# Altium schematic sheet STM32.SchDoc(STM32)
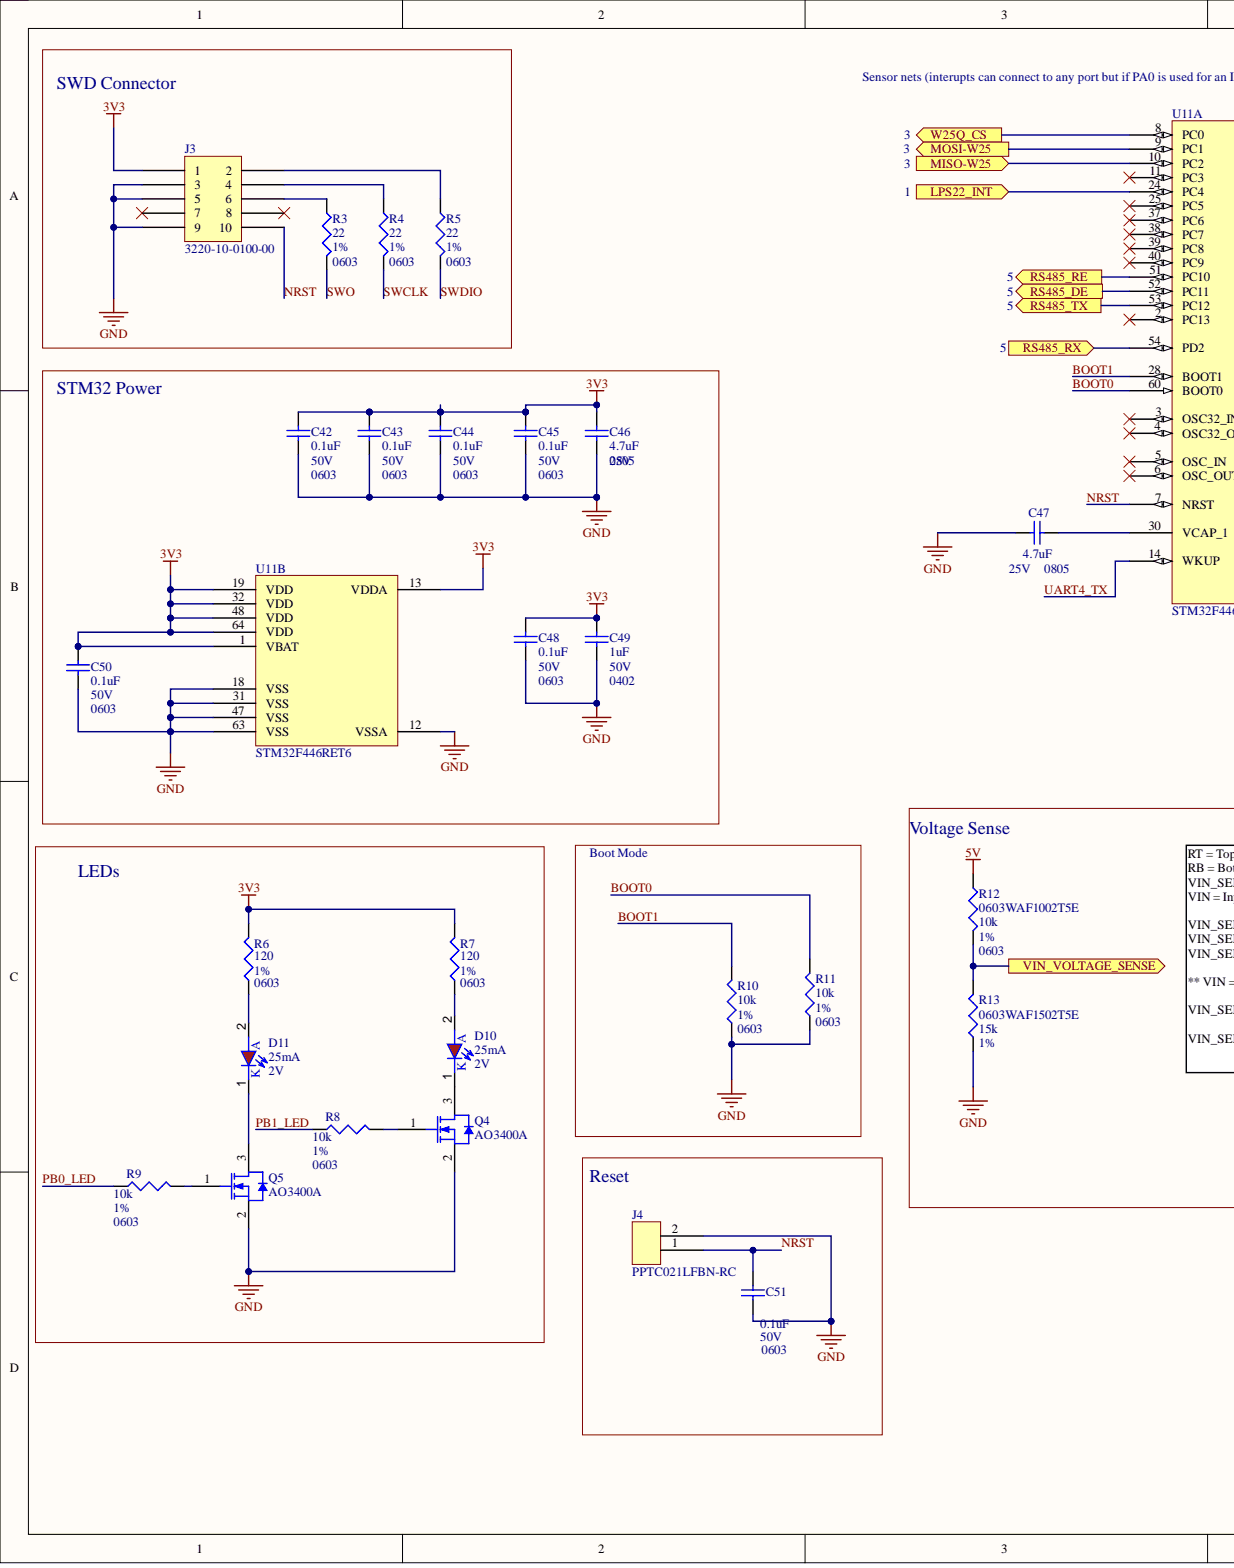
[diagram p0: STM32.SchDoc, left]
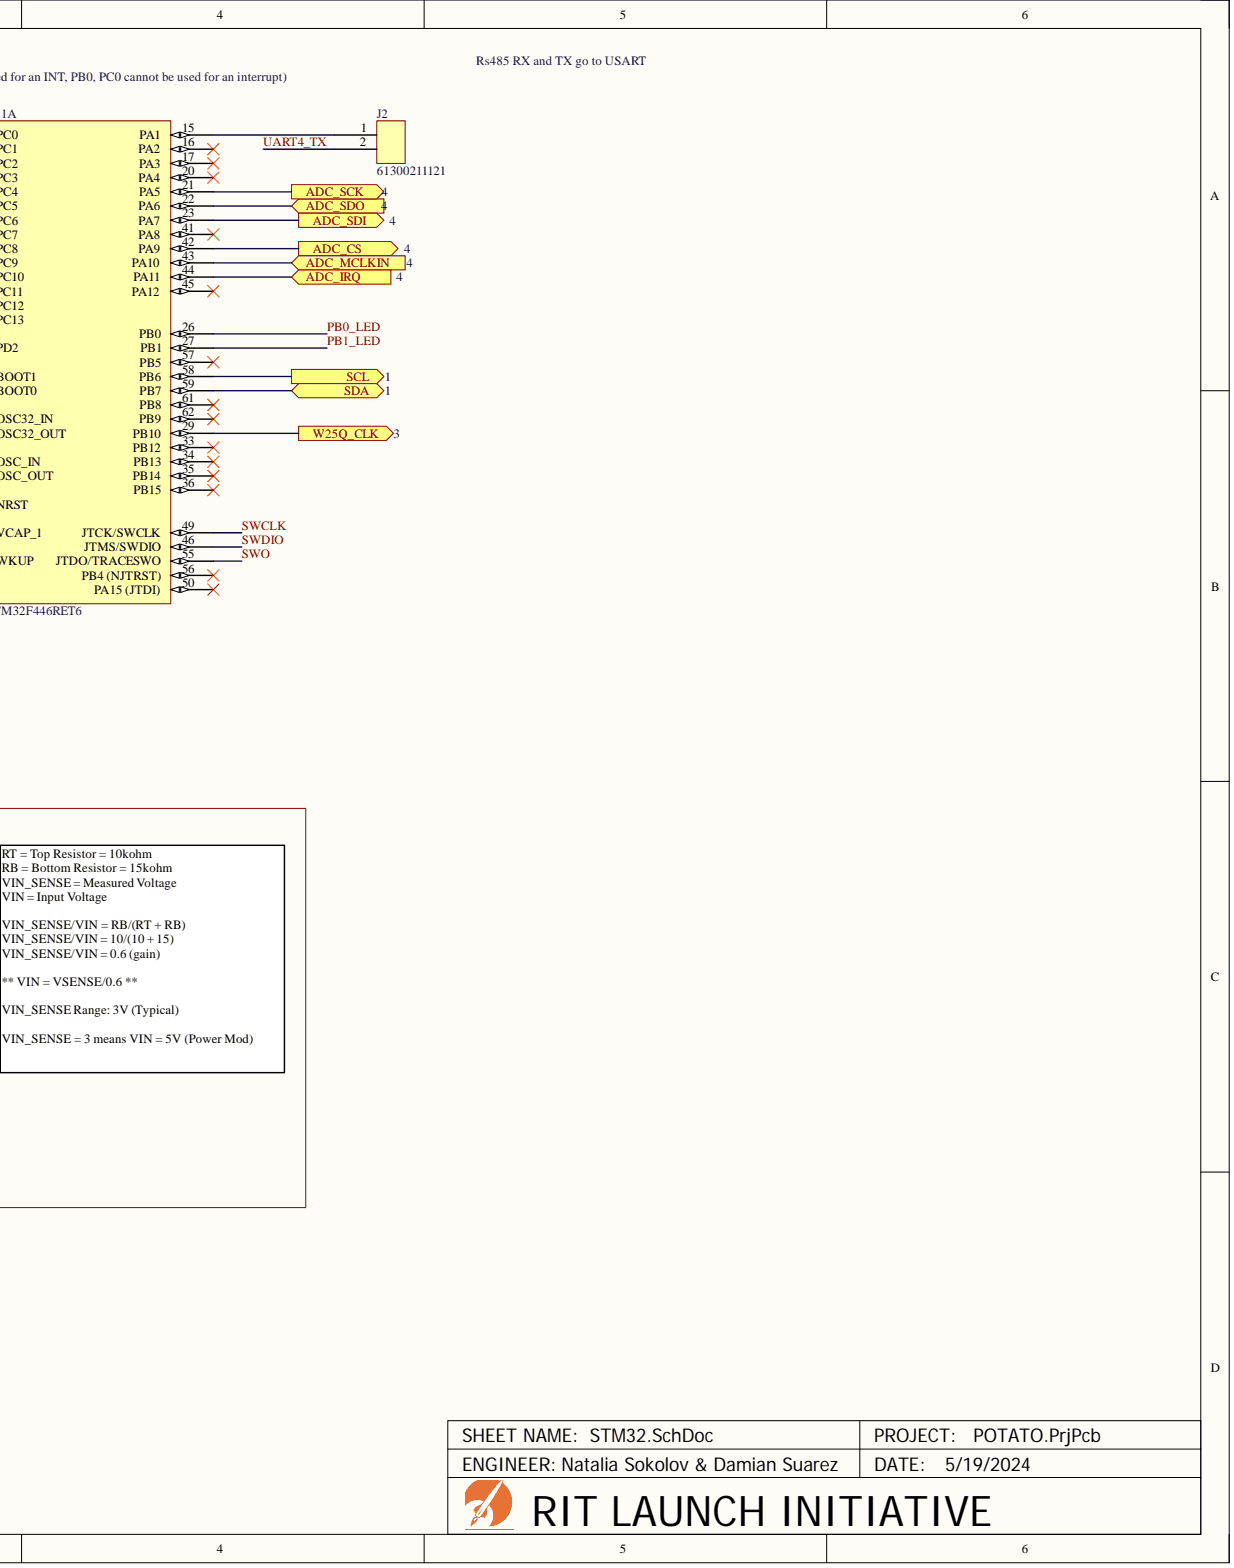
[diagram p1: STM32.SchDoc, right]

## Parts
C42  Capacitance: 0.1uF  Case Code (Imperial): 0603  Voltage: 50V  (hidden comment: CC0603KRX7R9BB104)
C43  Capacitance: 0.1uF  Case Code (Imperial): 0603  Voltage: 50V  (hidden comment: CC0603KRX7R9BB104)
C44  Capacitance: 0.1uF  Case Code (Imperial): 0603  Voltage: 50V  (hidden comment: CC0603KRX7R9BB104)
C45  Capacitance: 0.1uF  Case Code (Imperial): 0603  Voltage: 50V  (hidden comment: CC0603KRX7R9BB104)
C46  Capacitance: 4.7uF  Case/Package: 0805  Voltage: 25V  (hidden comment: CL21A475KAQNNNE)
C47  Capacitance: 4.7uF  Case/Package: 0805  Voltage: 25V  (hidden comment: CL21A475KAQNNNE)
C48  Capacitance: 0.1uF  Case Code (Imperial): 0603  Voltage: 50V  (hidden comment: CC0603KRX7R9BB104)
C49  Capacitance: 1uF  Case/Package: 0402  Voltage: 50V  (hidden comment: CL05A105KA5NQNC)
C50  Capacitance: 0.1uF  Case Code (Imperial): 0603  Voltage: 50V  (hidden comment: CC0603KRX7R9BB104)
C51  Capacitance: 0.1uF  Case Code (Imperial): 0603  Voltage: 50V  (hidden comment: CC0603KRX7R9BB104)
D10  Forward Voltage: 2V  Max Current: 25mA  (hidden comment: NCD0805R1)
D11  Forward Voltage: 2V  Max Current: 25mA  (hidden comment: NCD0805R1)
J2  61300211121
J3  3220-10-0100-00
J4  PPTC021LFBN-RC
Q4  AO3400A
Q5  AO3400A
R3  Case/Package: 0603  Tolerance: 1%  (hidden comment: 0603WAF220JT5E)
R4  Case/Package: 0603  Tolerance: 1%  (hidden comment: 0603WAF220JT5E)
R5  Case/Package: 0603  Tolerance: 1%  (hidden comment: 0603WAF220JT5E)
R6  Case/Package: 0603  Tolerance: 1%  (hidden comment: 0603WAF1200T5E)
R7  Case/Package: 0603  Tolerance: 1%  (hidden comment: 0603WAF1200T5E)
R8  0603WAF1002T5E  Case/Package: 0603  Resistance: 10k  Tolerance: 1%
R9  0603WAF1002T5E  Case/Package: 0603  Resistance: 10k  Tolerance: 1%
R10  0603WAF1002T5E  Case/Package: 0603  Resistance: 10k  Tolerance: 1%
R11  0603WAF1002T5E  Case/Package: 0603  Resistance: 10k  Tolerance: 1%
R12  0603WAF1002T5E  Case/Package: 0603  Resistance: 10k  Tolerance: 1%
R13  0603WAF1502T5E  Resistance: 15k  Tolerance: 1%
U11  STM32F446RET6  (parts A,B)

## Ports
ADC_CS, ADC_IRQ, ADC_MCLKIN, ADC_SCK, ADC_SDI, ADC_SDO, LPS22_INT, MISO-W25, MOSI-W25, RS485_DE, RS485_RE, RS485_RX, RS485_TX, SCL, SDA, VIN_VOLTAGE_SENSE, W25Q_CLK, W25Q_CS

## Nets
3V3: C42.2 C43.2 C44.2 C45.2 C46.2 C48.2 C49.2 C50.2 J3.1 R6.2 R7.2 U11.1(VBAT) U11.13(VDDA) U11.19(VDD) U11.32(VDD) U11.48(VDD) U11.64(VDD)
5V: R12.1
GND: C42.1 C43.1 C44.1 C45.1 C46.1 C47.1 C48.1 C49.1 C50.1 C51.1 J3.3 J3.5 J3.9 J4.2 Q4.2 Q5.2 R10.1 R11.1 R13.1 U11.12(VSSA) U11.18(VSS) U11.31(VSS) U11.47(VSS) U11.63(VSS)
ADC_CS: U11.42(PA9) ; port ADC_CS
ADC_IRQ: U11.44(PA11) ; port ADC_IRQ
ADC_MCLKIN: U11.43(PA10) ; port ADC_MCLKIN
ADC_SCK: U11.21(PA5) ; port ADC_SCK
ADC_SDI: U11.23(PA7) ; port ADC_SDI
ADC_SDO: U11.22(PA6) ; port ADC_SDO
BOOT0: R11.2 U11.60(BOOT0)
BOOT1: R10.2 U11.28(BOOT1)
LPS22_INT: U11.24(PC4) ; port LPS22_INT
MISO-W25: U11.10(PC2) ; port MISO-W25
MOSI-W25: U11.9(PC1) ; port MOSI-W25
NRST: C51.2 J3.10 J4.1 U11.7(NRST)
PB0_LED: R9.1 U11.26(PB0)
PB1_LED: R8.1 U11.27(PB1)
RS485_DE: U11.52(PC11) ; port RS485_DE
RS485_RE: U11.51(PC10) ; port RS485_RE
RS485_RX: U11.54(PD2) ; port RS485_RX
RS485_TX: U11.53(PC12) ; port RS485_TX
SCL: U11.58(PB6) ; port SCL
SDA: U11.59(PB7) ; port SDA
SWCLK: R4.2 U11.49(JTCK/SWCLK)
SWDIO: R5.2 U11.46(JTMS/SWDIO)
SWO: R3.2 U11.55(JTDO/TRACESWO)
UART4_TX: J2.2 U11.14(WKUP)
VIN_VOLTAGE_SENSE: R12.2 R13.2 ; port VIN_VOLTAGE_SENSE
W25Q_CLK: U11.29(PB10) ; port W25Q_CLK
W25Q_CS: U11.8(PC0) ; port W25Q_CS
NetC47_2: C47.2 U11.30(VCAP_1)
NetD10_1: D10.1 Q4.3
NetD10_2: D10.2 R7.1
NetD11_1: D11.1 Q5.3
NetD11_2: D11.2 R6.1
NetJ2_1: J2.1 U11.15(PA1)
NetJ3_2: J3.2 R5.1
NetJ3_4: J3.4 R4.1
NetJ3_6: J3.6 R3.1
NetQ4_1: Q4.1 R8.2
NetQ5_1: Q5.1 R9.2

Not connected: J3 7 8; U11 2(PC13) 3(OSC32_IN) 4(OSC32_OUT) 5(OSC_IN) 6(OSC_OUT) 11(PC3) 16(PA2) 17(PA3) 20(PA4) 25(PC5) 33(PB12) 34(PB13) 35(PB14) 36(PB15) 37(PC6) 38(PC7) 39(PC8) 40(PC9) 41(PA8) 45(PA12) 50(PA15_(JTDI)) 56(PB4_(NJTRST)) 57(PB5) 61(PB8) 62(PB9)

## Library data of the parts
C42-C45 C48 C50 C51 = CMP-001-0242-3: CAP CER 0.1UF 50V X7R 0603; Dielectric Material: Ceramic; Tolerance: 10%; Case Code (Metric): 1608; Case/Package: 0603; Component Kind: Standard; Depth: 0.8mm; Dielectric: X7R; Halogen Free: Halogen Free; Height: 0.8mm; JLCPCB Part #: C14663; Lead Free: Lead Free; Length: 0.063inch; Max Operating Temperature: 125°C; Min Operating Temperature: -55°C; Mount: Surface Mount; Number of Pins: 2; Package Quantity: 1; Packaging: Tape and Reel; Radiation Hardening: No; REACH SVHC: No SVHC; RoHS Compliant: Yes; Series: CC; Termination: SMD/SMT; Thickness: 0.035inch; Voltage Rating: 50V; Width: 0.031inch; Library Name: RIT Launch Initiative
C46 C47 = CMP-001-0285-2: 25V 4.7uF X5R ±10% 0805 Multilayer Ceramic Capacitors MLCC - SMD/SMT ROHS; Value: 4.7µF; Capacitor Type: Ceramic; Component Kind: Standard; JLCPCB Part #: C1779; Max Operating Temperature: 85°C; Min Operating Temperature: -55°C; Mounting Technology: Surface Mount; Package: 0805; Pins: 2; RoHS Compliant: Yes; Library Name: RIT Launch Initiative
C49 = CMP-001-0296-1: CAP CER 1uF 50V X5R 0402; Material: Ceramic; Tolerance: 10%; Component Kind: Standard; Dielectric: X5R; JLCPCB Part #: C52923; Max Operating Temperature: 85°C; Min Operating Temperature: -55°C; Mounting Technology: Surface Mount; Series: CL; Library Name: RIT Launch Initiative
D10 D11 = CMP-001-0246-3: LED RED CLEAR 0805 SMD; Component Kind: Standard; JLCPCB Part #: C84256; Library Name: RIT Launch Initiative
J2 = CMP-001-0080-1: CONN HEADER VERT 2POS 2.54MM; Component Kind: Standard; JLCPCB Part #: C2915055; Library Name: RIT Launch Initiative
J3 = CMP-001-0340-1: CONN HEADER VERT 10POS 1.27MM; Number of Positions: 10; Pitch: 0.05inch; Component Kind: Standard; Connector Type: Header; Contact Finish - Mating: GOLD; Contact Material: Brass; Contact Plating: Gold; Contact Shape: Square; Contact Type: Male Pin; Fastening Type: Pull; Flammability Rating: UL94 V-0; Insulation Material: Nylon; JLCPCB Part #: C5154224; Max Operating Temperature: 105°C; Min Operating Temperature: -40°C; Mounting Technology: Through Hole; Number of Rows: 2; Package Quantity: 3200; Packaging: Tray; RoHS Compliant: Yes; Row Spacing: 0.05inch; Series: -; Termination: Solder; Library Name: RIT Launch Initiative
J4 = CMP-001-0336-1: CONN HDR 2POS 0.1 TIN PCB; Connector Type: Header; Number of Positions: 2; Pitch: 2.54mm; Voltage Rating: 250V; Color: Black; Component Kind: Standard; Contact Finish - Mating: TIN; Contact Material: Bronze; Contact Plating: Tin; Contact Shape: Square; Contact Type: Female Socket; Fastening Type: Pull; Flammability Rating: UL94 V-0; Height: 8.5mm; Housing Material: Plastic; Insulation Color: Black; Insulation Material: Polyester; JLCPCB Part #: C3319545; Lead Free: Lead Free; Length: 5.58mm; Max Operating Temperature: 105°C; Min Operating Temperature: -40°C; Mounting Technology: Through Hole; Number of Rows: 1; Package Quantity: 1000; Packaging: Tray; RoHS Compliant: Yes; Series: -; Termination: Solder; Width: 2.5mm; Library Name: RIT Launch Initiative
Q4 Q5 = CMP-001-0127-4: MOSFET N-CH 30V 5.7A SOT23-3L; Gate to Source Voltage (Vgs): 12V; Input Capacitance: 630pF; Max Power Dissipation: 1.4W; Number of Elements: 1; Rds On Max: 26.5mR; Case/Package: TO-236-3; Component Kind: Standard; Continuous Drain Current (ID): 5.7A; Drain to Source Voltage (Vdss): 30V; JLCPCB Part #: C20917; Lead Free: Lead Free; Max Operating Temperature: 150°C; Min Operating Temperature: -55°C; Mounting Technology: Surface Mount; Packaging: Cut Tape; Pins: 3; Power Dissipation: 1.4W; Radiation Hardening: No; RoHS Compliant: Yes; Series: -; Library Name: RIT Launch Initiative
R3-R5 = CMP-001-0306-1: RES 22 OHM 1% 1/10W 0603; Resistance: 22; Component Kind: Standard; JLCPCB Part #: C23345; RoHS Compliant: Yes; Library Name: RIT Launch Initiative
R6 R7 = CMP-001-0272-1: RES 120 OHM 1% 1/10W 0603; Resistance: 120; Component Kind: Standard; JLCPCB Part #: C22787; Library Name: RIT Launch Initiative
R8-R12 = CMP-001-0268-1: RES 10K OHM 1% 1/10W 0603; Component Kind: Standard; JLCPCB Part #: C25804; RoHS Compliant: Yes; Library Name: RIT Launch Initiative
R13 = CMP-001-0407-2: 100mW Thick Film Resistors ±100ppm/℃ ±1% 15kΩ 0603 Chip Resistor - Surface Mount ROHS; Component Kind: Standard; JLCPCB Part #: C22809; Package: 0603; Library Name: RIT Launch Initiative
U11 = CMP-001-0264-3: IC MCU 32BIT 512KB FLASH 64LQFP; Core Architecture: ARM; Data Bus Width: 32b; Frequency: 180MHz; Interface: I2C,SPI,USB,CAN,IrDA,SD,UART,USART,LIN,EBI/EMI; Max Power Dissipation: 345mW; Max Supply Voltage: 3.6V; Min Supply Voltage: 1.7V; Number of I/Os: 50; Number of Timers/Counters: 14; Oscillator Type: Internal; Peripherals: Brown-out Detect/Reset,POR,PWM,WDT,DMA,LVD; RAM Size: 128KB; Watchdog Timer: Yes; Case/Package: LQFP; Component Kind: Standard; JLCPCB Part #: C69336; Lead Free: Lead Free; Max Frequency: 180MHz; Max Operating Temperature: 85°C; Memory Size: 512KB; Memory Type: FLASH; Min Operating Temperature: -40°C; Mount: Surface Mount; Number of Pins: 64; Number of Programmable I/O: 50; Packaging: Tray; REACH SVHC: No SVHC; RoHS Compliant: Yes; Series: STM32 F4; Library Name: RIT Launch Initiative
